# Altium schematic sheet CAN Schematic.SchDoc("CAN Schematic")
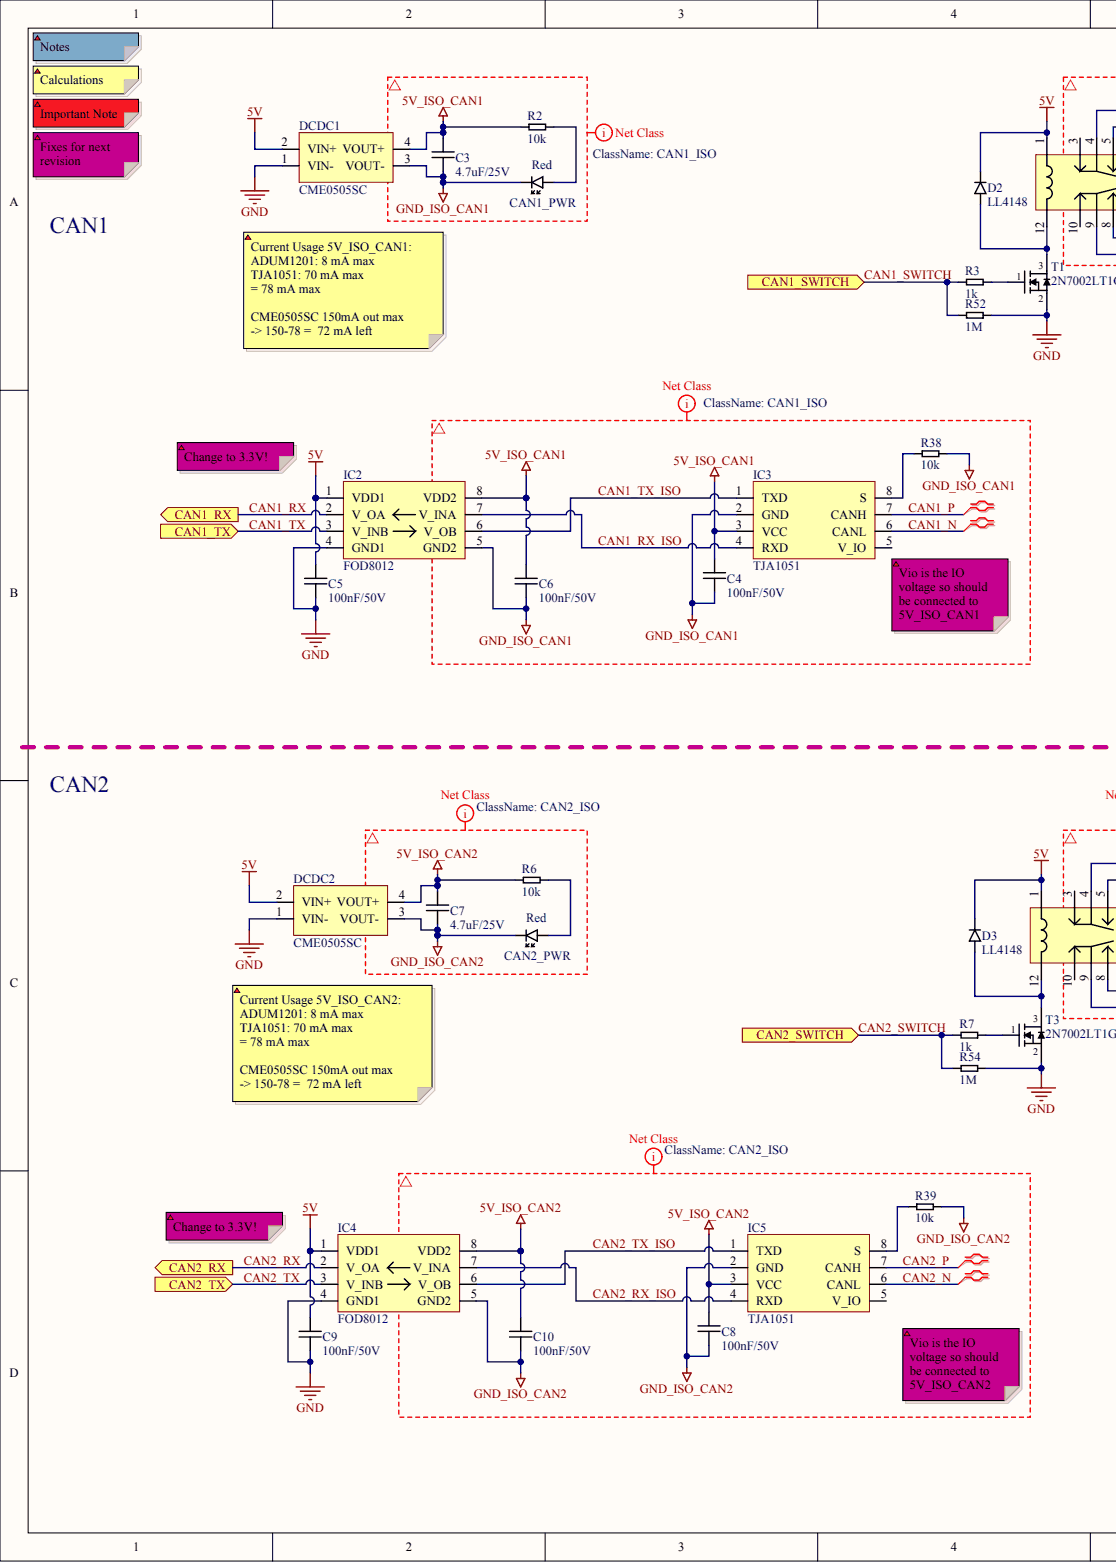
[diagram p0: CAN Schematic.SchDoc, left]
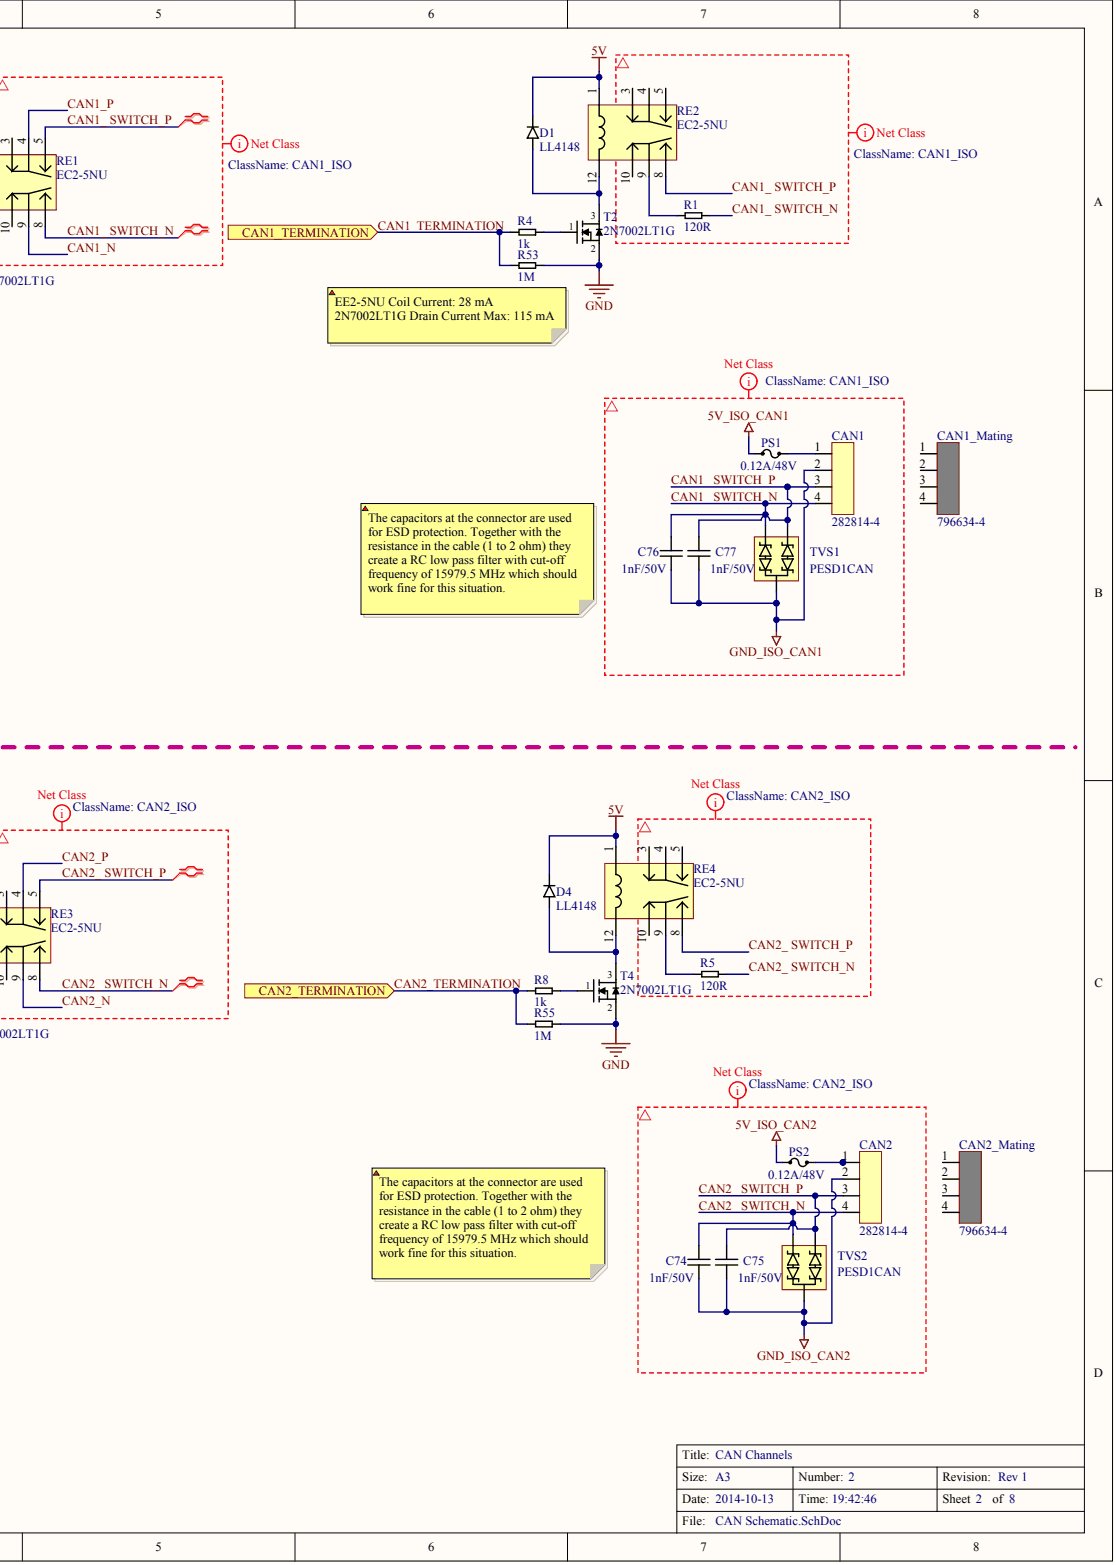
[diagram p1: CAN Schematic.SchDoc, right]

## Parts
C3  value 4.7uF/25V  [0805]  (hidden comment: Cap_0805_4.7uF/25V)
C4  value 100nF/50V  [0603]  (hidden comment: Cap_0603_100nF/50V)
C5  value 100nF/50V  [0603]  (hidden comment: Cap_0603_100nF/50V)
C6  value 100nF/50V  [0603]  (hidden comment: Cap_0603_100nF/50V)
C7  value 4.7uF/25V  [0805]  (hidden comment: Cap_0805_4.7uF/25V)
C8  value 100nF/50V  [0603]  (hidden comment: Cap_0603_100nF/50V)
C9  value 100nF/50V  [0603]  (hidden comment: Cap_0603_100nF/50V)
C10  value 100nF/50V  [0603]  (hidden comment: Cap_0603_100nF/50V)
C74  value 1nF/50V  [0603]  (hidden comment: Cap_0603_1nF/50V)
C75  value 1nF/50V  [0603]  (hidden comment: Cap_0603_1nF/50V)
C76  value 1nF/50V  [0603]  (hidden comment: Cap_0603_1nF/50V)
C77  value 1nF/50V  [0603]  (hidden comment: Cap_0603_1nF/50V)
CAN1  value 282814-4  Part No.: 282814-4  (hidden comment: Terminal Block 1x4 5.08mm)
CAN1_Mating  value 796634-4  Part No.: 796634-4  (hidden comment: Terminal Block 1x4 5.08mm Mating Connector)
CAN1_PWR  value Red  (hidden comment: LED_0603_Red)
CAN2  value 282814-4  Part No.: 282814-4  (hidden comment: Terminal Block 1x4 5.08mm)
CAN2_Mating  value 796634-4  Part No.: 796634-4  (hidden comment: Terminal Block 1x4 5.08mm Mating Connector)
CAN2_PWR  value Red  (hidden comment: LED_0603_Red)
D1  LL4148
D2  LL4148
D3  LL4148
D4  LL4148
DCDC1  CME0505SC  [4-SIP]
DCDC2  CME0505SC  [4-SIP]
IC2  FOD8012
IC3  TJA1051
IC4  FOD8012
IC5  TJA1051
PS1  value 0.12A/48V  [SMD 1206]  (hidden comment: Polyswitch_1206_0.12Ahold_48V)
PS2  value 0.12A/48V  [SMD 1206]  (hidden comment: Polyswitch_1206_0.12Ahold_48V)
R1  value 120R  [0603]  (hidden comment: Res_0603_120R)
R2  value 10k  [0603]  (hidden comment: Res_0603_10k)
R3  value 1k  [0603]  (hidden comment: Res_0603_1k)
R4  value 1k  [0603]  (hidden comment: Res_0603_1k)
R5  value 120R  [0603]  (hidden comment: Res_0603_120R)
R6  value 10k  [0603]  (hidden comment: Res_0603_10k)
R7  value 1k  [0603]  (hidden comment: Res_0603_1k)
R8  value 1k  [0603]  (hidden comment: Res_0603_1k)
R38  value 10k  [0603]  (hidden comment: Res_0603_10k)
R39  value 10k  [0603]  (hidden comment: Res_0603_10k)
R52  value 1M  [0603]  (hidden comment: Res_0603_1M)
R53  value 1M  [0603]  (hidden comment: Res_0603_1M)
R54  value 1M  [0603]  (hidden comment: Res_0603_1M)
R55  value 1M  [0603]  (hidden comment: Res_0603_1M)
RE1  value EC2-5NU  Part No.: EC2-5NU  (hidden comment: Relay EC2-5NU)
RE2  value EC2-5NU  Part No.: EC2-5NU  (hidden comment: Relay EC2-5NU)
RE3  value EC2-5NU  Part No.: EC2-5NU  (hidden comment: Relay EC2-5NU)
RE4  value EC2-5NU  Part No.: EC2-5NU  (hidden comment: Relay EC2-5NU)
T1  2N7002LT1G  [SOT-23]
T2  2N7002LT1G  [SOT-23]
T3  2N7002LT1G  [SOT-23]
T4  2N7002LT1G  [SOT-23]
TVS1  PESD1CAN
TVS2  PESD1CAN

## Ports
CAN1_RX, CAN1_SWITCH, CAN1_TERMINATION, CAN1_TX, CAN2_RX, CAN2_SWITCH, CAN2_TERMINATION, CAN2_TX

## Nets
5V: C5.2 C9.2 D1.1 D2.1 D3.1 D4.1 DCDC1.2(VIN+) DCDC2.2(VIN+) IC2.1(VDD1) IC4.1(VDD1) RE1.1 RE2.1 RE3.1 RE4.1
5V_ISO_CAN1: C3.2 C4.2 C6.2 DCDC1.4(VOUT+) IC2.8(VDD2) IC3.3(VCC) PS1.1 R2.2
5V_ISO_CAN2: C7.2 C8.2 C10.2 DCDC2.4(VOUT+) IC4.8(VDD2) IC5.3(VCC) PS2.1 R6.2
GND: C5.1 C9.1 DCDC1.1(VIN-) DCDC2.1(VIN-) IC2.4(GND1) IC4.4(GND1) R52.2 R53.2 R54.2 R55.2 T1.2 T2.2 T3.2 T4.2
GND_ISO_CAN1: C3.1 C4.1 C6.1 C76.1 C77.1 CAN1.2 CAN1_PWR.CATHODE DCDC1.3(VOUT-) IC2.5(GND2) IC3.2(GND) R38.1 TVS1.3
GND_ISO_CAN2: C7.1 C8.1 C10.1 C74.1 C75.1 CAN2.2 CAN2_PWR.CATHODE DCDC2.3(VOUT-) IC4.5(GND2) IC5.2(GND) R39.1 TVS2.3
CAN1_ SWITCH_N: C76.2 CAN1.4 R1.2 RE1.8 TVS1.2
CAN1_ SWITCH_P: C77.2 CAN1.3 RE1.5 RE2.8 TVS1.1
CAN1_N: IC3.6(CANL) RE1.9
CAN1_P: IC3.7(CANH) RE1.4
CAN1_RX: IC2.2(V_OA) ; port CAN1_RX
CAN1_RX_ISO: IC2.7(V_INA) IC3.4(RXD)
CAN1_SWITCH: R3.1 R52.1 ; port CAN1_SWITCH
CAN1_TERMINATION: R4.1 R53.1 ; port CAN1_TERMINATION
CAN1_TX: IC2.3(V_INB) ; port CAN1_TX
CAN1_TX_ISO: IC2.6(V_OB) IC3.1(TXD)
CAN2_ SWITCH_N: C74.2 CAN2.4 R5.2 RE3.8 TVS2.2
CAN2_ SWITCH_P: C75.2 CAN2.3 RE3.5 RE4.8 TVS2.1
CAN2_N: IC5.6(CANL) RE3.9
CAN2_P: IC5.7(CANH) RE3.4
CAN2_RX: IC4.2(V_OA) ; port CAN2_RX
CAN2_RX_ISO: IC4.7(V_INA) IC5.4(RXD)
CAN2_SWITCH: R7.1 R54.1 ; port CAN2_SWITCH
CAN2_TERMINATION: R8.1 R55.1 ; port CAN2_TERMINATION
CAN2_TX: IC4.3(V_INB) ; port CAN2_TX
CAN2_TX_ISO: IC4.6(V_OB) IC5.1(TXD)
NetCAN1_1: CAN1.1 PS1.2
NetCAN1_PWR_ANODE: CAN1_PWR.ANODE R2.1
NetCAN2_1: CAN2.1 PS2.2
NetCAN2_PWR_ANODE: CAN2_PWR.ANODE R6.1
NetD1_2: D1.2 RE2.12 T2.3
NetD2_2: D2.2 RE1.12 T1.3
NetD3_2: D3.2 RE3.12 T3.3
NetD4_2: D4.2 RE4.12 T4.3
NetIC3_8: IC3.8(S) R38.2
NetIC5_8: IC5.8(S) R39.2
NetR1_1: R1.1 RE2.9
NetR3_2: R3.2 T1.1
NetR4_2: R4.2 T2.1
NetR5_1: R5.1 RE4.9
NetR7_2: R7.2 T3.1
NetR8_2: R8.2 T4.1
N00104:
N00105:
N00106:
N00107:
N00108:
N00109:
N00110:
N00111:
N00112:
N00113:

Not connected: CAN1_Mating 1 2 3 4; CAN2_Mating 1 2 3 4; IC3 5(V_IO); IC5 5(V_IO); RE1 3 10; RE2 3 4 5 10; RE3 3 10; RE4 3 4 5 10

## Library data of the parts
CAN1 CAN2 = (no symbol name): Manufacturer: TE Connectivity; Mating Connector: 796634-4; Mouser: 571-282814-4; RS: *; DigiKey: A98067-ND; Elfa: *; Price SEK (No VAT): 8
CAN1_Mating CAN2_Mating = (no symbol name): Manufacturer: TE Connectivity; Mouser: 571-7966344; RS: *; DigiKey: A98225-ND; Elfa: *; Price SEK (No VAT): 18
D1-D4 = (no symbol name): Manufacturer: Fairchild Semiconductor; Mouser: 512-LL4148; DigiKey: LL4148FSCT-ND; Value: LL4148; Price SEK (No VAT): 1; Part No.: LL4148
DCDC1 DCDC2 = (no symbol name): Manufacturer: Murata; DigiKey: 811-2894-5-ND; Part No.: CME0505SC; Mouser: 580-CME0505SC; Datasheet: http://www.murata-ps.com/data/power/ncl/kdc_cme.pdf; Price SEK (No VAT): 28
IC2 IC4 = (no symbol name): Manufacturer: Fairchild Semiconductor; Note: Should be able to replace ADUM1201 as pin-compatible; DigiKey: FOD8012-ND; Part No.: FOD8012; Price SEK (No VAT): 31; Datasheet: http://www.fairchildsemi.com/ds/FO/FOD8012.pdf; Mouser: 512-FOD8012; Value: FOD8012
IC3 IC5 = (no symbol name): Part No.: TJA1051T/3,118; Manufacturer: NXP; DigiKey: 568-8684-1-ND; Datasheet: http://www.nxp.com/documents/data_sheet/TJA1051.pdf; Mouser: 771-TJA1051T3118; Price SEK (No VAT): 7
PS1 PS2 = (no symbol name): Part No.: NANOSMDC012F-2; Manufacturer: TE Connectivity; RS: 782-6455; Mouser: 650-NANOSMDC012F-2; Price SEK (No VAT): 2; DigiKey: NANOSMDC012FCT-ND; Farnell: 1345937
R4 = (no symbol name): Value: 1k; Price (No VAT): 0,1
RE1-RE4 = (no symbol name): Manufacturer: Kemet; Mouser: 80-EC2-5NU; DigiKey: 399-11052-5-ND; Price SEK (No VAT): 12; Datasheet: http://www.kemet.com/Lists/ProductCatalog/Attachments/527/KEM_R7002_EC2_EE2.pdf
T1-T4 = (no symbol name): Manufacturer: ON Semiconductor; Mouser: 863-2N7002LT1G; Part No.: 2N7002LT1G; DigiKey: 2N7002LT1GOSCT-ND; Price SEK (No VAT): 2; Value: 2N7002LT1G
TVS1 TVS2 = (no symbol name): Manufacturer: NXP; Part No.: PESD1CAN,215; Mouser: 771-PESD1CAN-T/R; DigiKey: 568-4032-1-ND; Price SEK (No VAT): 4; Value: PESD1CAN
C3-C10 C74-C77 CAN1_PWR CAN2_PWR R1-R3 R5-R8 R38 R39 R52-R55 = (no symbol name)
  R1-R3 R5-R8 R38 R39 R52-R55: Price (No VAT): 0,1
